# Altium schematic sheet Piezo Driver.SchDoc("Piezo Driver")
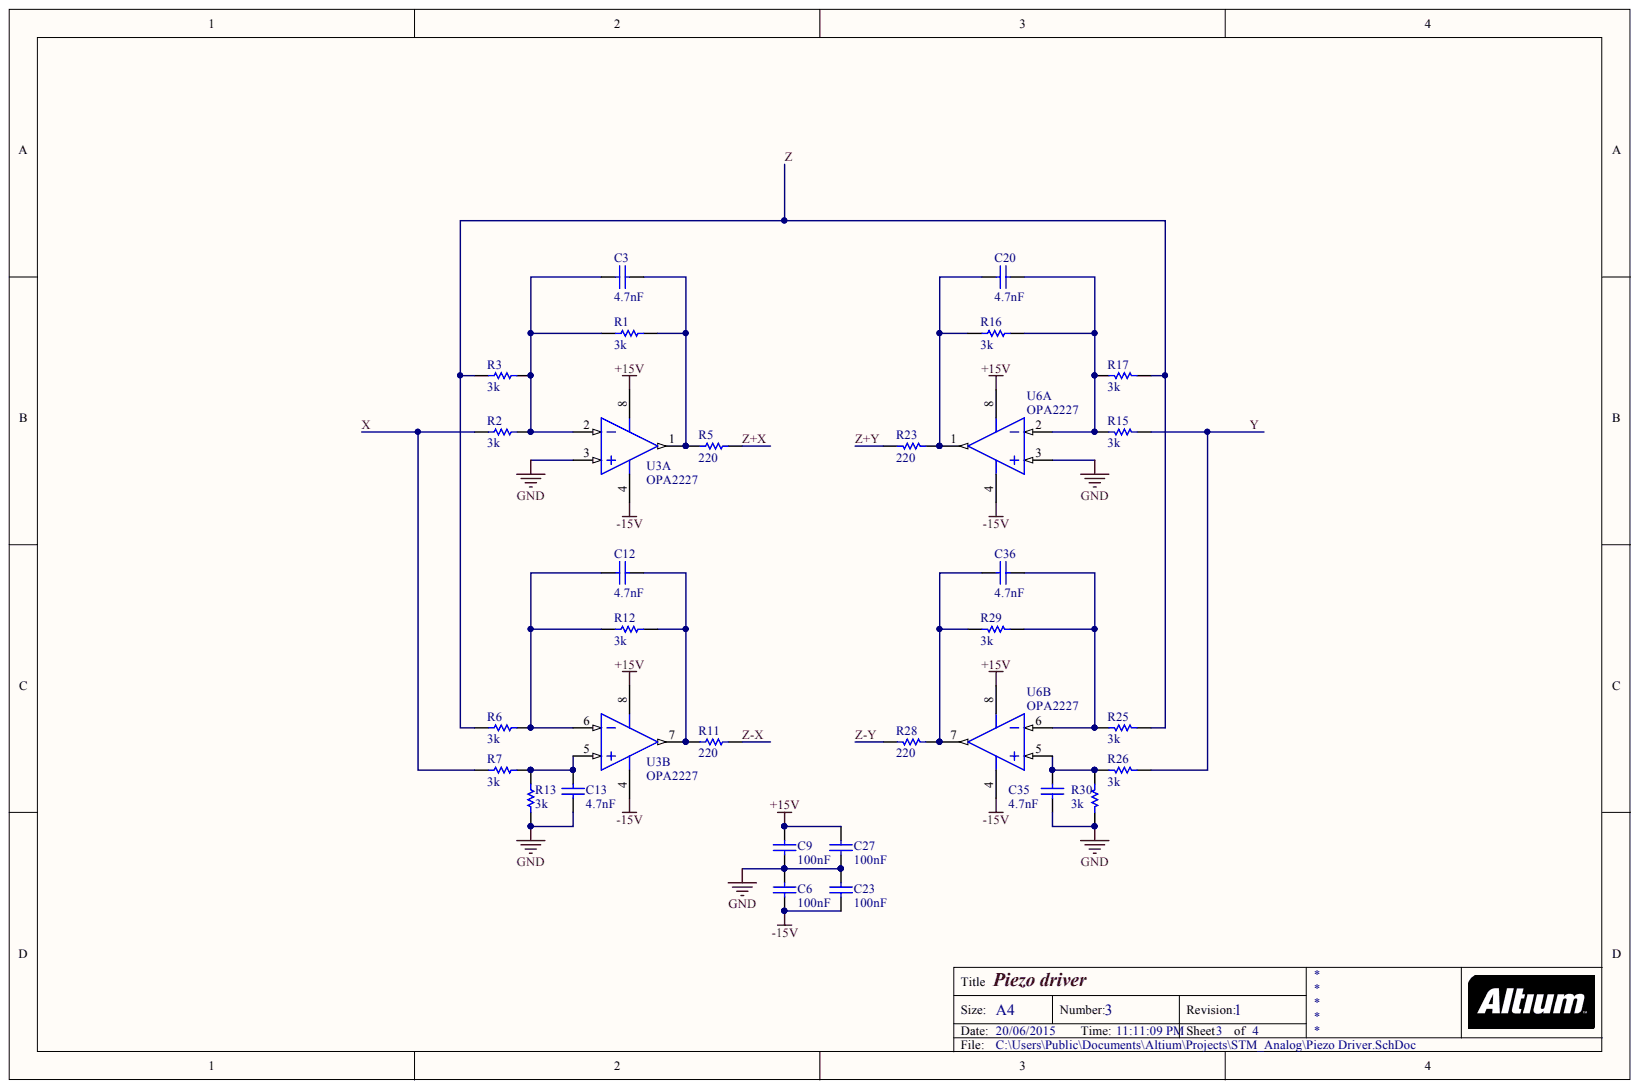
[diagram p0: Piezo Driver.SchDoc]

## Parts
C3  4.7nF  [6-0805_N]
C6  100nF  [6-0805_N]
C9  100nF  [6-0805_N]
C12  4.7nF  [6-0805_N]
C13  4.7nF  [6-0805_N]
C20  4.7nF  [6-0805_N]
C23  100nF  [6-0805_N]
C27  100nF  [6-0805_N]
C35  4.7nF  [6-0805_N]
C36  4.7nF  [6-0805_N]
R1  3k  [6-0805_N]
R2  3k  [6-0805_N]
R3  3k  [6-0805_N]
R5  220  [6-0805_N]
R6  3k  [6-0805_N]
R7  3k  [6-0805_N]
R11  220  [6-0805_N]
R12  3k  [6-0805_N]
R13  3k  [6-0805_N]
R15  3k  [6-0805_N]
R16  3k  [6-0805_N]
R17  3k  [6-0805_N]
R23  220  [6-0805_N]
R25  3k  [6-0805_N]
R26  3k  [6-0805_N]
R28  220  [6-0805_N]
R29  3k  [6-0805_N]
R30  3k  [6-0805_N]
U3  OPA2227  [ADI-R-8_M]  (parts A,B)
U6  OPA2227  [ADI-R-8_M]  (parts A,B)

## Nets
+15V: C9.2 C27.2 U3.8 U6.8
-15V: C6.1 C23.1 U3.4 U6.4
GND: C6.2 C9.1 C13.1 C23.2 C27.1 C35.1 R13.1 R30.1 U3.3 U6.3
X: R2.1 R7.1
Y: R15.1 R26.1
Z: R3.1 R6.1 R17.1 R25.1
Z+X: R5.2
Z+Y: R23.2
Z-X: R11.2
Z-Y: R28.2
NetC3_1: C3.1 R1.1 R2.2 R3.2 U3.2
NetC3_2: C3.2 R1.2 R5.1 U3.1
NetC12_1: C12.1 R6.2 R12.1 U3.6
NetC12_2: C12.2 R11.1 R12.2 U3.7
NetC13_2: C13.2 R7.2 R13.2 U3.5
NetC20_1: C20.1 R16.1 R23.1 U6.1
NetC20_2: C20.2 R15.2 R16.2 R17.2 U6.2
NetC35_2: C35.2 R26.2 R30.2 U6.5
NetC36_1: C36.1 R28.1 R29.2 U6.7
NetC36_2: C36.2 R25.2 R29.1 U6.6

## Library data of the parts
C3 C6 C9 C12 C13 C20 C23 C27 C35 C36 = Cap: Capacitor; Value: 100pF; Component Kind: Standard; LatestRevisionDate: 17-Jul-2002; LatestRevisionNote: Re-released for DXP Platform.; Library Name: Miscellaneous Devices.IntLib; PackageDescription: Radial Cap, Thru-Hole; 2 Leads; 0.3 in Pin Spacing; PackageReference: RAD-0.3; Published: 8-Jun-2000; Simulation: CAP; Publisher: Altium Limited
R1-R3 R5-R7 R11-R13 R15-R17 R23 R25 R26 R28-R30 = Res1: Resistor; Value: 1K; Component Kind: Standard; LatestRevisionDate: 17-Jul-2002; LatestRevisionNote: Re-released for DXP Platform.; Library Name: Miscellaneous Devices.IntLib; PackageDescription: Axial Device, Thru-Hole; 2 Leads; 0.3 in Pin Spacing; PackageReference: AXIAL-0.3; Published: 8-Jun-2000; Simulation: RESISTOR; Publisher: Altium Limited
U3 U6 = CMP-0384-01147-2: Double Channel Wide Bandwidth Operational Amplifier, 10 MHz BW, 2.5 V/us SR, Industrial, 8-pin SOIC (R-8), Tube; Generic Part Number: AD8676; Manufacturer: Analog Devices; PartNumber: AD8676BRZ; Amplifiers Per Package: 2; Input Bias Current: 2.4nA; Input Offset Voltage: 12uV; Iq/Amp: 2.7mA; Small Signal Bandwidth: 10MHz; V Span Max: 36V; V Span Min: 10V; Code_JEDEC: MS-012-AA; Component Kind: Standard; ComponentLink1Description: Manufacturer URL; ComponentLink1URL: http://www.analog.com/en/index.html; ComponentLink2Description: Datasheet; ComponentLink2URL: http://www.analog.com/static/imported-files/data_sheets/AD8676.pdf; ComponentLink3Description: Package Specification; ComponentLink3URL: http://www.analog.com/static/imported-files/packages/PKG_PDF/SOIC_Narrow%20(R)/R_8.pdf; ComponentLink4Description: Reference Circuit; ComponentLink4URL: http://www.analog.com/en/all-operational-amplifiers-op-amps/operational-amplifiers-op-amps/ad8676/products/product.html#product-recommendations; DatasheetVersion: Rev. C; Library Name: Altium Content Vault; Mounting Technology: Surface Mount; PackageDescription: 8-Pin Standard Small Outline Package, Body 3.9 x 4.9 mm, Pitch 1.27 mm; PackageReference: R-8; PackageVersion: 060506-A; RevisionNote: Reference Circuit URL fixed; Slew Rate: 2.5V/us
